# Altium schematic sheet SprayCtrll-INPUT.SchDoc
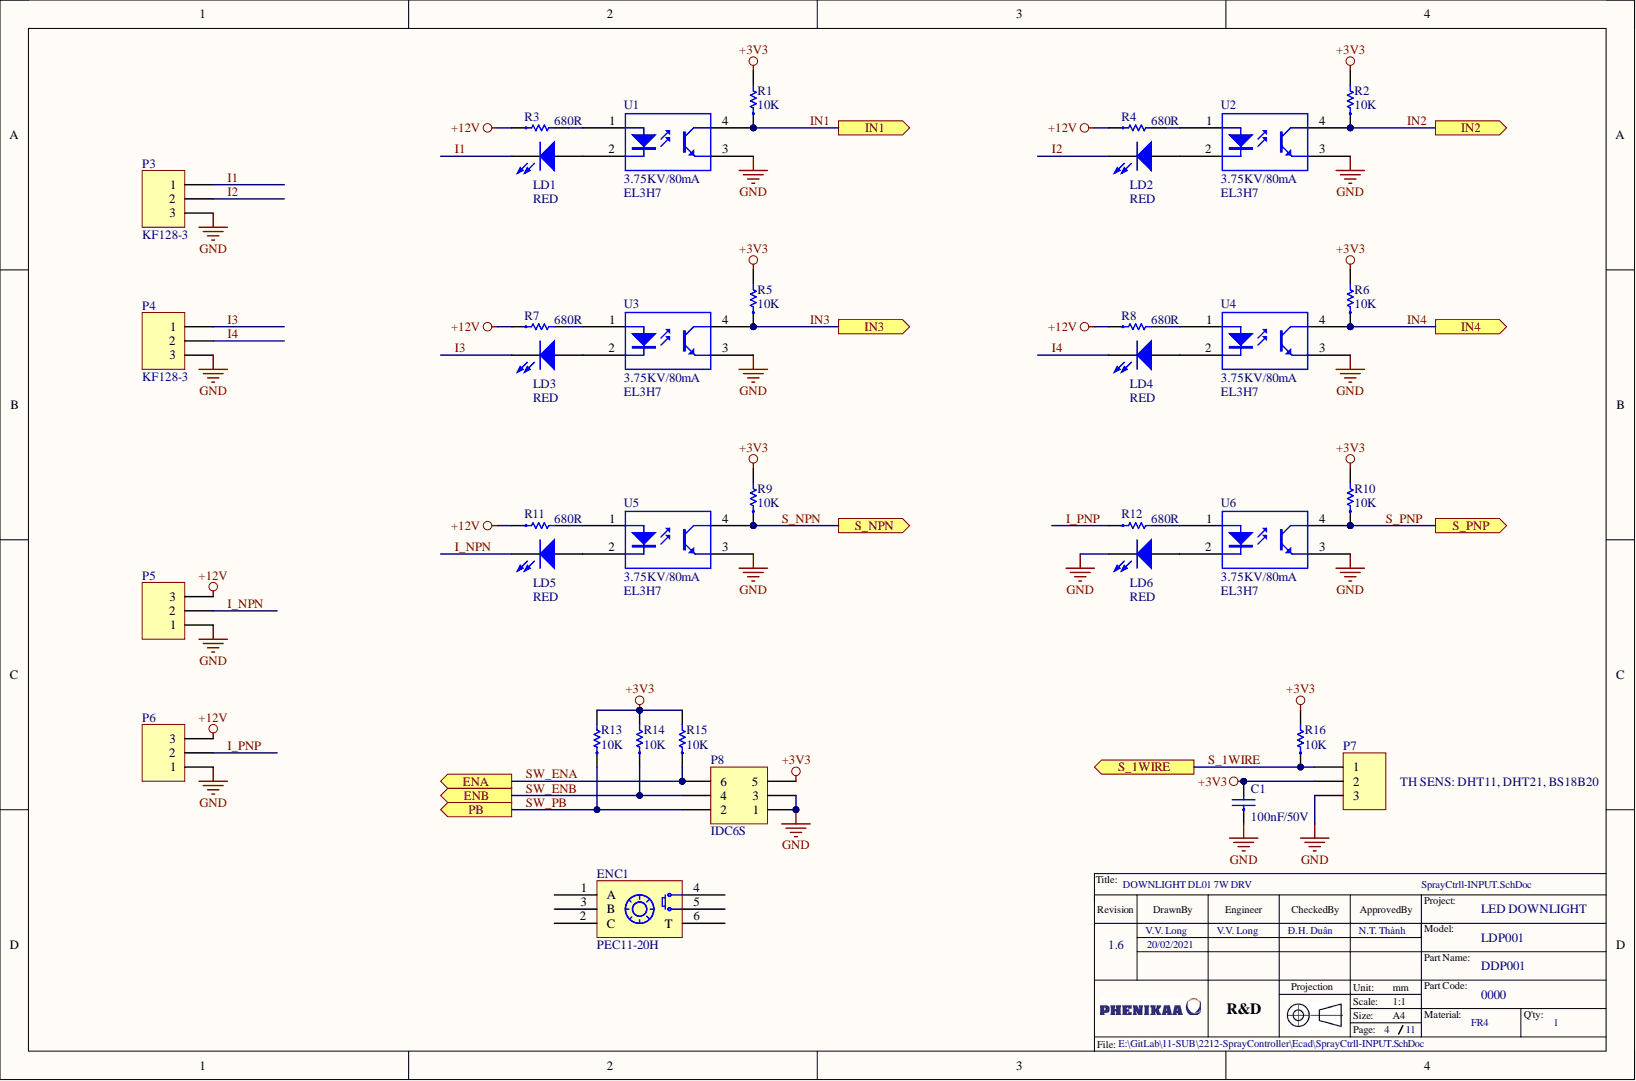
[diagram p0: SprayCtrll-INPUT.SchDoc]

## Parts
C1  100nF/50V  [CAP-CER0603-0.8H]
ENC1  PEC11-20H  CLASS: B  [ENC-EPC11-20H-THR]
LD1  RED  [LED-IND0805-RED-0.8H]
LD2  RED  [LED-IND0805-RED-0.8H]
LD3  RED  [LED-IND0805-RED-0.8H]
LD4  RED  [LED-IND0805-RED-0.8H]
LD5  RED  [LED-IND0805-RED-0.8H]
LD6  RED  [LED-IND0805-RED-0.8H]
P3  KF128-3  [CON-TER-KF128V-03]
P4  KF128-3  [CON-TER-KF128V-03]
P5  XH2.54-3S  [CON-XH2.54-3S]  (value not drawn)
P6  XH2.54-3S  [CON-XH2.54-3S]  (value not drawn)
P7  XH2.54-3S  [CON-XH2.54-3S]  (value not drawn)
P8  IDC6S  [CON-IDC6-2.54P9.3H-S-THR]
R1  10K  [RES-CHP0603-0.6H]
R2  10K  [RES-CHP0603-0.6H]
R3  680R  [RES-CHP1206-0.65H]
R4  680R  [RES-CHP1206-0.65H]
R5  10K  [RES-CHP0603-0.6H]
R6  10K  [RES-CHP0603-0.6H]
R7  680R  [RES-CHP1206-0.65H]
R8  680R  [RES-CHP1206-0.65H]
R9  10K  [RES-CHP0603-0.6H]
R10  10K  [RES-CHP0603-0.6H]
R11  680R  [RES-CHP1206-0.65H]
R12  680R  [RES-CHP1206-0.65H]
R13  10K  [RES-CHP0603-0.6H]
R14  10K  [RES-CHP0603-0.6H]
R15  10K  [RES-CHP0603-0.6H]
R16  10K  [RES-CHP0603-0.6H]
U1  3.75KV/80mA  NOTE: EL3H7  [SSOP4-2.0H]
U2  3.75KV/80mA  NOTE: EL3H7  [SSOP4-2.0H]
U3  3.75KV/80mA  NOTE: EL3H7  [SSOP4-2.0H]
U4  3.75KV/80mA  NOTE: EL3H7  [SSOP4-2.0H]
U5  3.75KV/80mA  NOTE: EL3H7  [SSOP4-2.0H]
U6  3.75KV/80mA  NOTE: EL3H7  [SSOP4-2.0H]

## Ports
ENA, ENB, IN1, IN2, IN3, IN4, PB, S_1WIRE, S_NPN, S_PNP

## Nets
+3V3: C1.2 P7.2 P8.5 R1.2 R2.2 R5.2 R6.2 R9.2 R10.2 R13.2 R14.2 R15.2 R16.2
+12V: P5.3 P6.3 R3.1 R4.1 R7.1 R8.1 R11.1
GND: C1.1 LD6.2 P3.3 P4.3 P5.1 P6.1 P7.3 P8.1 P8.3 U1.3 U2.3 U3.3 U4.3 U5.3 U6.3
I1: LD1.2 P3.1
I2: LD2.2 P3.2
I3: LD3.2 P4.1
I4: LD4.2 P4.2
I_NPN: LD5.2 P5.2
I_PNP: P6.2 R12.1
IN1: R1.1 U1.4 ; port IN1
IN2: R2.1 U2.4 ; port IN2
IN3: R5.1 U3.4 ; port IN3
IN4: R6.1 U4.4 ; port IN4
S_1WIRE: P7.1 R16.1 ; port S_1WIRE
S_NPN: R9.1 U5.4 ; port S_NPN
S_PNP: R10.1 U6.4 ; port S_PNP
SW_ENA: P8.6 R15.1 ; port ENA
SW_ENB: P8.4 R14.1 ; port ENB
SW_PB: P8.2 R13.1 ; port PB
NetLD1_1: LD1.1 U1.2
NetLD2_1: LD2.1 U2.2
NetLD3_1: LD3.1 U3.2
NetLD4_1: LD4.1 U4.2
NetLD5_1: LD5.1 U5.2
NetLD6_1: LD6.1 U6.2
NetR3_2: R3.2 U1.1
NetR4_2: R4.2 U2.1
NetR7_2: R7.2 U3.1
NetR8_2: R8.2 U4.1
NetR11_2: R11.2 U5.1
NetR12_2: R12.2 U6.1

Not connected: ENC1 1(A) 2(C) 3(B) 4 5 6(T)

## Library data of the parts
C1 = CAPCER0015 SMD0603 100nF/50V: CAP CER 100nF 10% 50V X5R 0603 SMD; MFR PN: SAMWHA-CS1608X5R104K500NRB; CERTIFICATION: NA; CLASS: B; LINE: SMT; PHX CODE: 100100024; TYPE: EP; UNIT: PCS; Component Kind: Standard; Library Name: ADLibEP.IntLib; MOUNT: SMD; PHX PN: CAPCER0015
ENC1 = ENCMEC0001 PEC11-20H: ENC MEC 20PR 2B 1S QUA PEC11-20H THR; MFR PN: BOURNS-PEC11L-4120F-S0020; CERTIFICATION: NA; LINE: DIP; PHX CODE: 102300001; UNIT: PCS; Component Kind: Standard; Library Name: ADLibEP.IntLib; MOUNT: MI; PHX PN: ENCMEC0001; TYPE: EP
LD1-LD6 = LEDIND0001 SMD0805 RED: LED IND RED CLR 2V 20mA 0805 SMD; MFR PN: WURTH-150080RS75000; CERTIFICATION: NA; CLASS: B; LINE: SMT; PHX CODE: 100600010; TYPE: EP; UNIT: PCS; Component Kind: Standard; Library Name: ADLibEP.IntLib; MOUNT: SMD; PHX PN: LEDIND0001
P3 P4 = CONTER0000 KF128-3: CON TER 1R2C KF128-3 5.0P THR; CERTIFICATION: NA; CLASS: A; LINE: DIP; UNIT: PCS; Component Kind: Standard; Library Name: ADLibEP.IntLib; MFR PN: NA; MOUNT: MI; PHX CODE: NA; PHX PN: NA; TYPE: EP
P5-P7 = CONW2B0000 XH2.54 3S THR: CON W2B 1R3CM XH2.54 3S THR; CERTIFICATION: NA; LINE: DIP; UNIT: PCS; CLASS: NA; Component Kind: Standard; Library Name: ADLibEP.IntLib; MFR PN: NA; MOUNT: MI; NOTE: NA; PHX CODE: NA; PHX PN: NA; TYPE: EP
P8 = CONW2B0000 IDC2.54 6S THR: CON W2B 2R3CM IDC6S 2.54P THR; CERTIFICATION: NA; LINE: DIP; UNIT: PCS; CLASS: NA; Component Kind: Standard; Library Name: ADLibEP.IntLib; MFR PN: NA; MOUNT: MI; NOTE: NA; PHX CODE: NA; PHX PN: NA; TYPE: EP
R1 R2 R5 R6 R9 R10 R13-R16 = RESCHP0143 SMD0603 1% 10K: RES CHP 10K 1% 1/10W 0603 SMD; MFR PN: SUNKA-VSR-0603-10K-K; CERTIFICATION: NA; CLASS: B; LINE: SMT; PHX CODE: 100800170; UNIT: PCS; Component Kind: Standard; Library Name: ADLibEP.IntLib; MOUNT: SMD; PHX PN: RESCHP0143; TYPE: EP
R3 R4 R7 R8 R11 R12 = RESCHP0000 SMD1206 5% 680R: RES CHP 680R 5% 1/4W 1206 SMD; MFR PN: SUNKA-VSR-1206-680R-J; CERTIFICATION: NA; CLASS: B; LINE: SMT; PHX CODE: (SAP); UNIT: PCS; Component Kind: Standard; Library Name: ADLibEP.IntLib; MOUNT: SMD; PHX PN: RESCHP0000; TYPE: EP
U1-U6 = OPTTRA0001 4SSOP 3.75KV/80mA: OPT TRA 1CH 3.75KV 80mA 4SMD SMD; MFR PN: Everlight-EL3H7(B)(TA)-VG; CERTIFICATION: NA; CLASS: B; LINE: SMT; PHX CODE: 101900001; UNIT: PCS; Component Kind: Standard; Library Name: ADLibEP.IntLib; MOUNT: SMD; PHX PN: OPTTRA0001; TYPE: EP
